# Altium schematic sheet Sheet3.SchDoc(Sheet3)
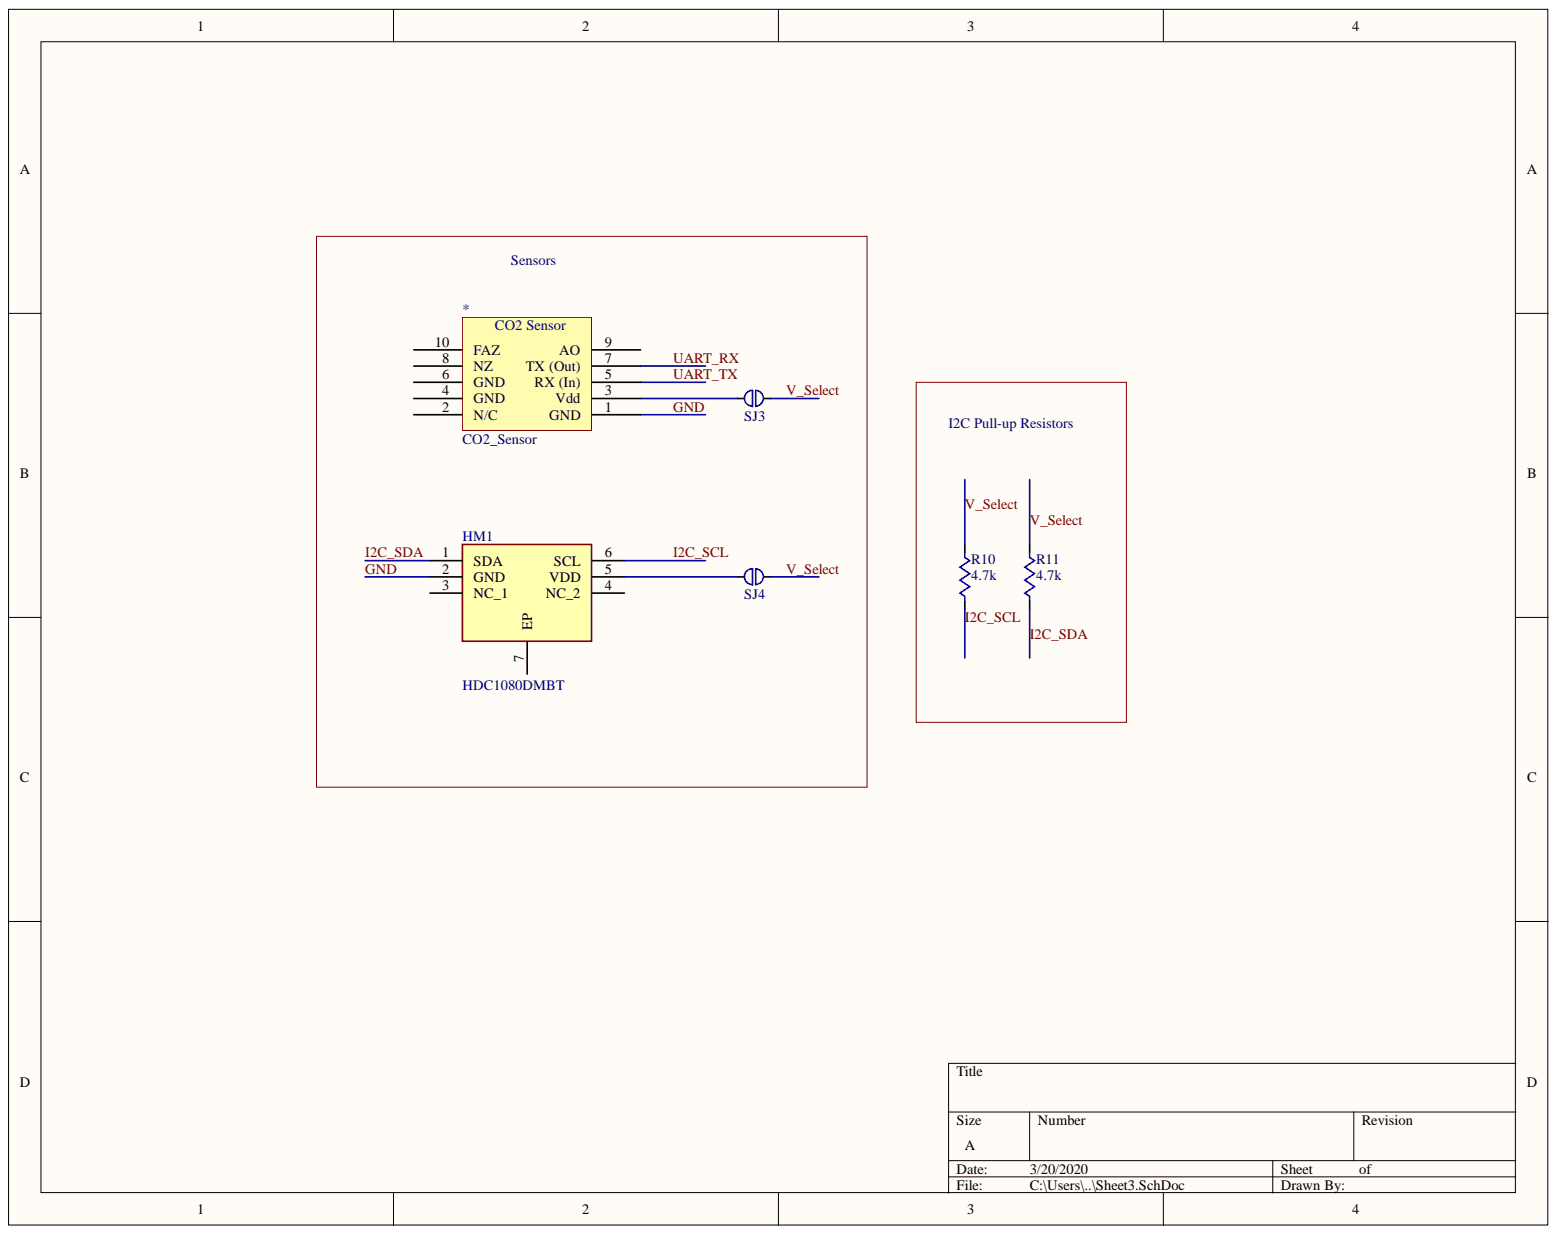
[diagram p0: Sheet3.SchDoc]

## Parts
*  CO2_Sensor  [CO2_Sensor]
HM1  HDC1080DMBT  [SON100P300X300X80-7N]
R10  value 4.7k  [PASSIVE-RESISTOR-0603]  (hidden comment: Passive-Resistor (Generic))
R11  value 4.7k  [PASSIVE-RESISTOR-0603]  (hidden comment: Passive-Resistor (Generic))
SJ3  Debug-Solder-Jumper  [DEBUG-SOLDER-JUMPER]  (value not drawn)  (no BOM)
SJ4  Debug-Solder-Jumper  [DEBUG-SOLDER-JUMPER]  (value not drawn)  (no BOM)

## Nets
GND: *.1(GND) HM1.2(GND)
I2C_SCL: HM1.6(SCL) R10.1
I2C_SDA: HM1.1(SDA) R11.1
UART_RX: *.7(TX_(Out))
UART_TX: *.5(RX_(In))
V_Select: R10.2 R11.2 SJ3.2 SJ4.2
Net*_3: *.3(Vdd) SJ3.1
NetHM1_5: HM1.5(VDD) SJ4.1

Not connected: * 2(N/C) 4(GND) 6(GND) 8(NZ) 9(AO) 10(FAZ); HM1 3(NC_1) 4(NC_2) 7(EP)

## Library data of the parts
HM1 = HDC1080DMBT: Hygrometer; Manufacturer_Name: Texas Instruments; RS Price/Stock: http://uk.rs-online.com/web/p/products/1330170P; RS Part Number: 1330170P; Mouser Price/Stock: https://www.mouser.com/Search/Refine.aspx?Keyword=595-HDC1080DMBT; Mouser Part Number: 595-HDC1080DMBT; Manufacturer_Part_Number: HDC1080DMBT; Height: 0.8mm; Datasheet Link: http://www.mouser.com/ds/2/405/hdc1080-844688.pdf; Component Kind: Standard; Library Name: EnvironmentalSensor.IntLib
R10 R11 = Passive-Resistor (Generic): Generic Resistor; Through Hole (y/n): N; Supplier 2: Digi-Key; Supplier 1: Mouser; Library Name: MasterLib.IntLib; Component Kind: Standard; Category: Passive
SJ3 SJ4 = Debug-Solder-Jumper: 3.5mm Diameter, Solder Jumper Pad; Library Name: MasterLib.IntLib; Category: Debug
* = CO2_Sensor: Component Kind: Standard; Library Name: Enviornmental_Sensor.IntLib
